# Altium schematic sheet Sheet1.SchDoc
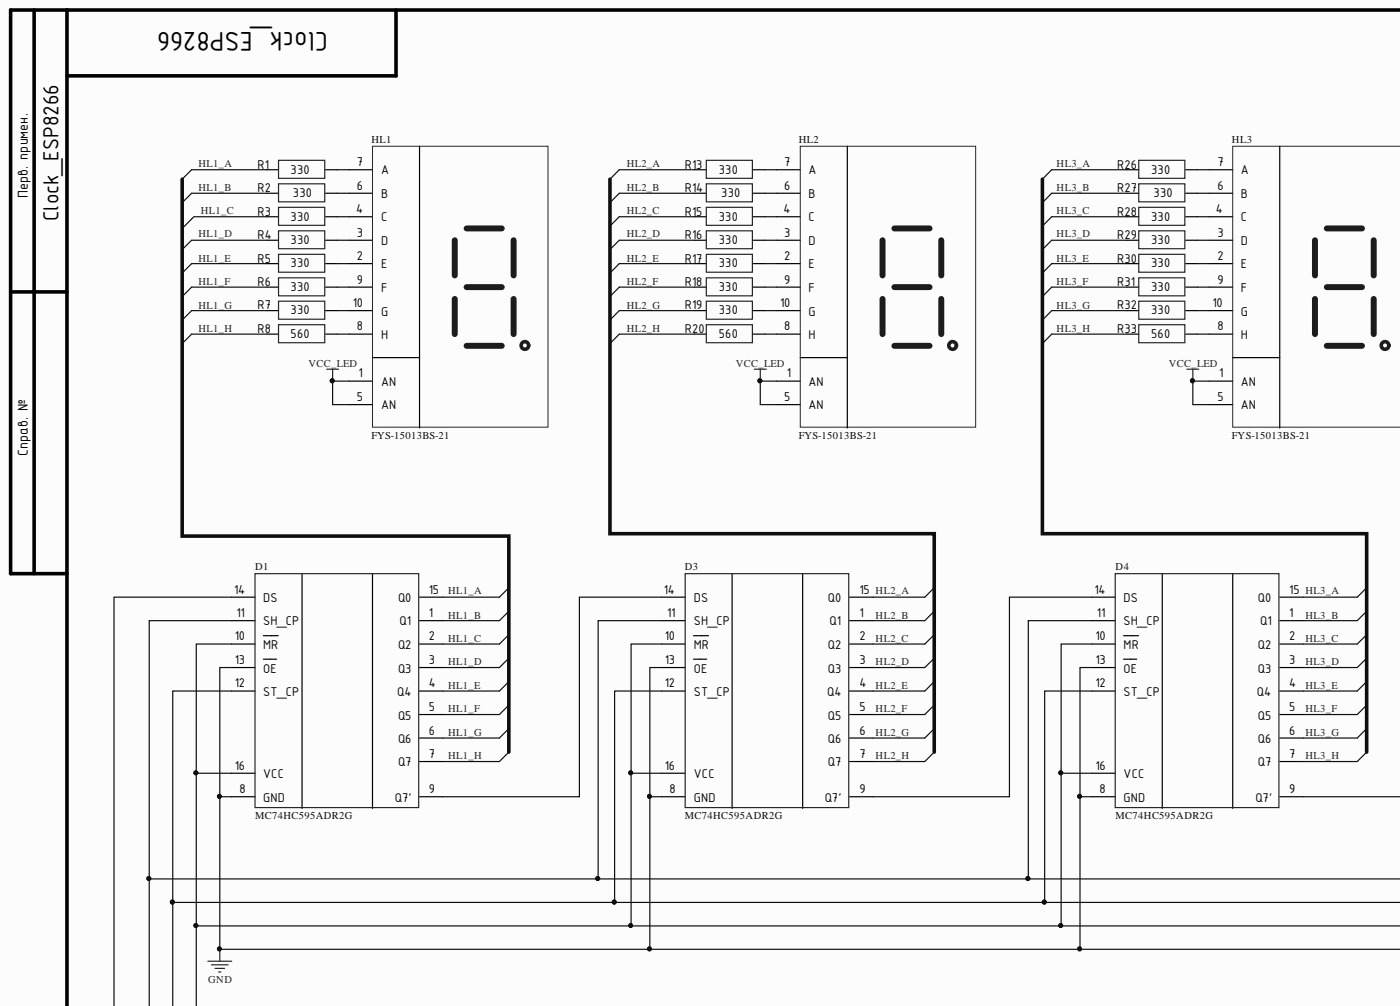
[diagram p0: Sheet1.SchDoc, top left]
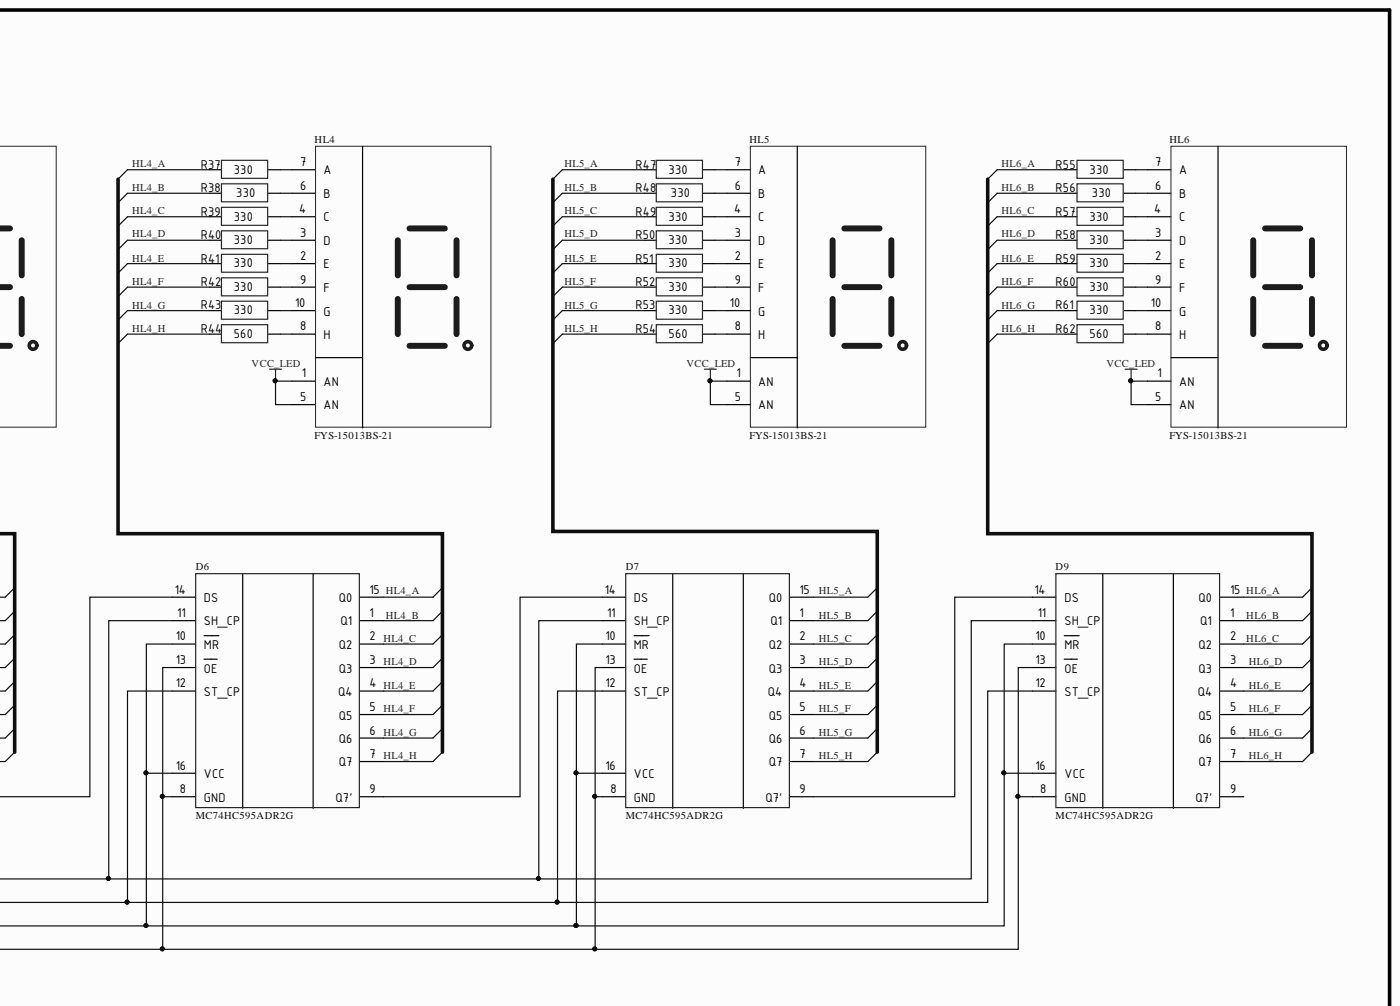
[diagram p1: Sheet1.SchDoc, top right]
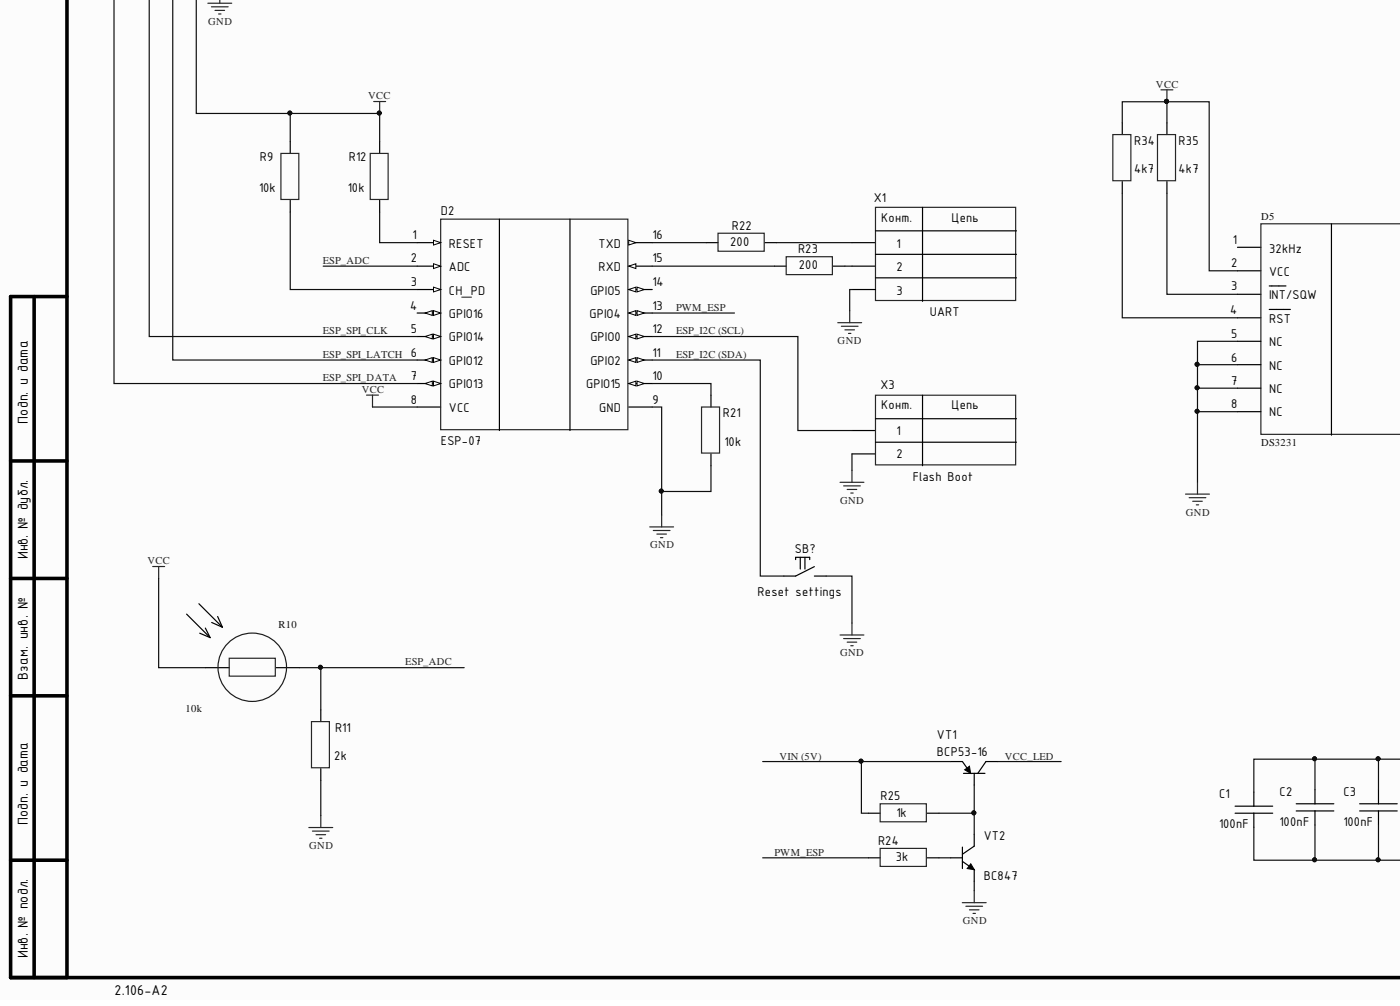
[diagram p2: Sheet1.SchDoc, bottom left]
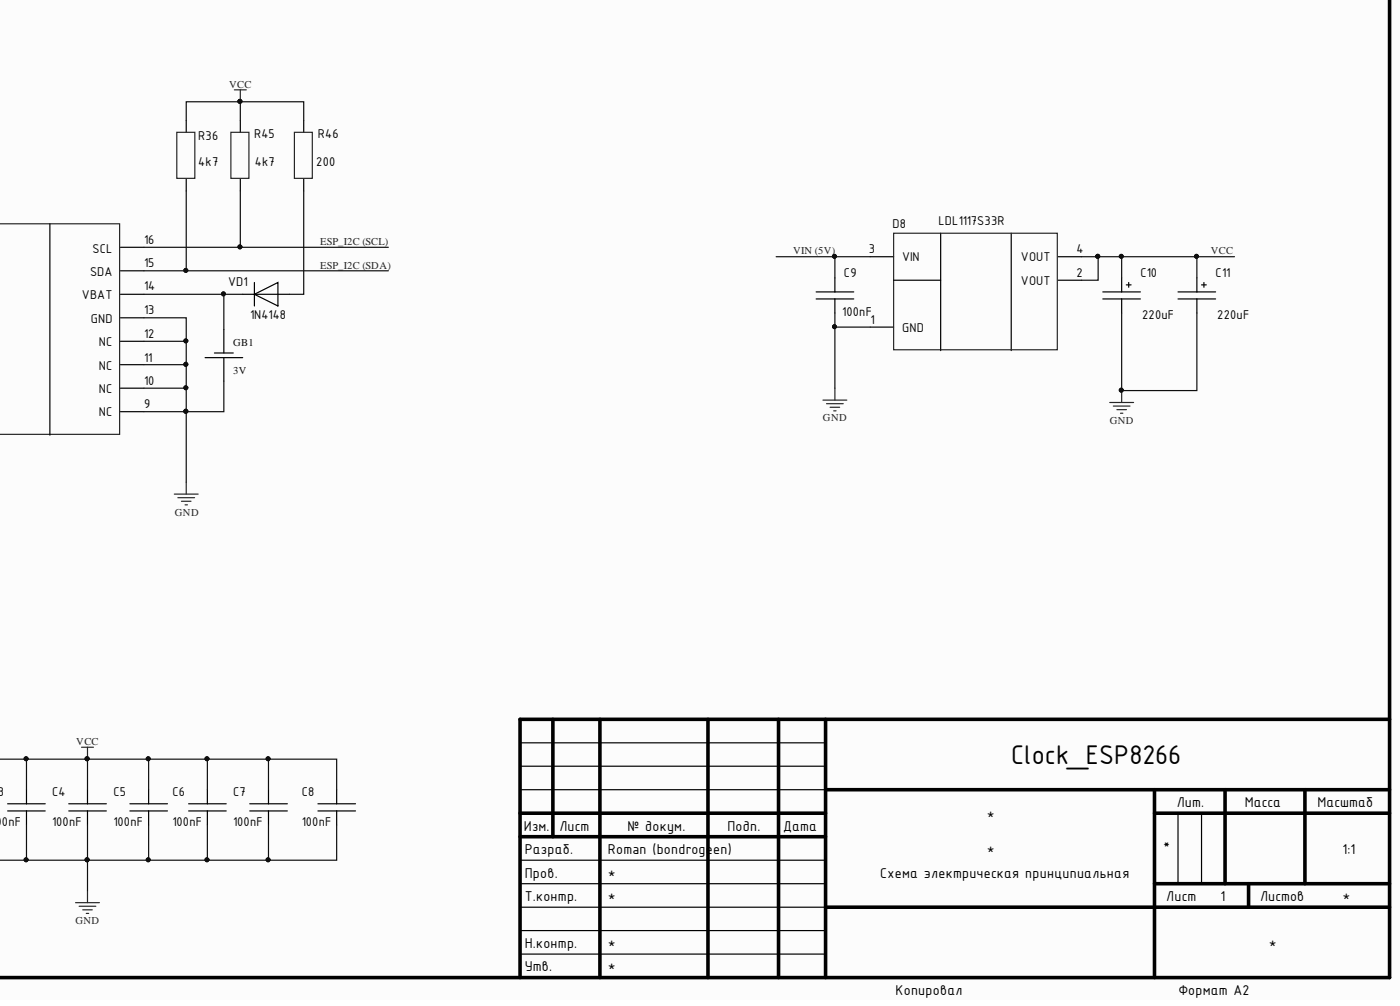
[diagram p3: Sheet1.SchDoc, bottom right]

## Parts
C1  100nF  [CH0603C]
C2  100nF  [CH0603C]
C3  100nF  [CH0603C]
C4  100nF  [CH0603C]
C5  100nF  [CH0603C]
C6  100nF  [CH0603C]
C7  100nF  [CH0603C]
C8  100nF  [CH0603C]
C9  100nF  [CH0805C]
C10  220uF  [TANTAL_C]
C11  220uF  [TANTAL_C]
D1  MC74HC595ADR2G  [16-SOIC]
D2  ESP-07  [MOD: ESP-12E]
D3  MC74HC595ADR2G  [16-SOIC]
D4  MC74HC595ADR2G  [16-SOIC]
D5  DS3231  [16-SOIC (W)]
D6  MC74HC595ADR2G  [16-SOIC]
D7  MC74HC595ADR2G  [16-SOIC]
D8  LDL1117S33R  [SOT223]
D9  MC74HC595ADR2G  [16-SOIC]
GB1  3V  [KLS5-CR2032-01]
HL1  FYS-15013BS-21  [7-seg ( 44 X 30.6)]
HL2  FYS-15013BS-21  [7-seg ( 44 X 30.6)]
HL3  FYS-15013BS-21  [7-seg ( 44 X 30.6)]
HL4  FYS-15013BS-21  [7-seg ( 44 X 30.6)]
HL5  FYS-15013BS-21  [7-seg ( 44 X 30.6)]
HL6  FYS-15013BS-21  [7-seg ( 44 X 30.6)]
R1  330  [CH0805R]
R2  330  [CH0805R]
R3  330  [CH0805R]
R4  330  [CH0805R]
R5  330  [CH0805R]
R6  330  [CH0805R]
R7  330  [CH0805R]
R8  560  [CH0805R]
R9  10k  [CH0805R]
R10  10k  [CON: PBS-2]
R11  2k  [CH0805R]
R12  10k  [CH0805R]
R13  330  [CH0805R]
R14  330  [CH0805R]
R15  330  [CH0805R]
R16  330  [CH0805R]
R17  330  [CH0805R]
R18  330  [CH0805R]
R19  330  [CH0805R]
R20  560  [CH0805R]
R21  10k  [CH0805R]
R22  200  [CH0805R]
R23  200  [CH0805R]
R24  3k  [CH0805R]
R25  1k  [CH0805R]
R26  330  [CH0805R]
R27  330  [CH0805R]
R28  330  [CH0805R]
R29  330  [CH0805R]
R30  330  [CH0805R]
R31  330  [CH0805R]
R32  330  [CH0805R]
R33  560  [CH0805R]
R34  4k7  [CH0805R]
R35  4k7  [CH0805R]
R36  4k7  [CH0805R]
R37  330  [CH0805R]
R38  330  [CH0805R]
R39  330  [CH0805R]
R40  330  [CH0805R]
R41  330  [CH0805R]
R42  330  [CH0805R]
R43  330  [CH0805R]
R44  560  [CH0805R]
R45  4k7  [CH0805R]
R46  200  [CH0805R]
R47  330  [CH0805R]
R48  330  [CH0805R]
R49  330  [CH0805R]
R50  330  [CH0805R]
R51  330  [CH0805R]
R52  330  [CH0805R]
R53  330  [CH0805R]
R54  560  [CH0805R]
R55  330  [CH0805R]
R56  330  [CH0805R]
R57  330  [CH0805R]
R58  330  [CH0805R]
R59  330  [CH0805R]
R60  330  [CH0805R]
R61  330  [CH0805R]
R62  560  [CH0805R]
SB?  Reset settings  [BUT: SKQGADE010]
VD1  1N4148  [SOD80]
VT1  BCP53-16  [SOT223]
VT2  BC847  [SOT23_123]
X1  UART  [CON: PBS-3]
X3  Flash Boot  [CON: PBS-2]

## Nets
GND: C1.1 C2.1 C3.1 C4.1 C5.1 C6.1 C7.1 C8.1 C9.1 C10.2 C11.2 D1.8(GND) D1.13(OE) D2.9(GND) D3.8(GND) D3.13(OE) D4.8(GND) D4.13(OE) D5.5(NC) D5.6(NC) D5.7(NC) D5.8(NC) D5.9(NC) D5.10(NC) D5.11(NC) D5.12(NC) D5.13(GND) D6.8(GND) D6.13(OE) D7.8(GND) D7.13(OE) D8.1(GND) D9.8(GND) D9.13(OE) GB1.2 R11.1 R21.1 SB?.2 VT2.3 X1.3 X3.2
ESP_ADC: D2.2(ADC) R10.2 R11.2
ESP_I2C (SCL): D2.12(GPIO0) D5.16(SCL) R45.1 X3.1
ESP_I2C (SDA): D2.11(GPIO2) D5.15(SDA) R36.1 SB?.1
ESP_SPI_CLK: D1.11(SH_CP) D2.5(GPIO14) D3.11(SH_CP) D4.11(SH_CP) D6.11(SH_CP) D7.11(SH_CP) D9.11(SH_CP)
ESP_SPI_DATA: D1.14(DS) D2.7(GPIO13)
ESP_SPI_LATCH: D1.12(ST_CP) D2.6(GPIO12) D3.12(ST_CP) D4.12(ST_CP) D6.12(ST_CP) D7.12(ST_CP) D9.12(ST_CP)
HL1_A: D1.15(Q0) R1.1
HL1_B: D1.1(Q1) R2.1
HL1_C: D1.2(Q2) R3.1
HL1_D: D1.3(Q3) R4.1
HL1_E: D1.4(Q4) R5.1
HL1_F: D1.5(Q5) R6.1
HL1_G: D1.6(Q6) R7.1
HL1_H: D1.7(Q7) R8.1
HL2_A: D3.15(Q0) R13.1
HL2_B: D3.1(Q1) R14.1
HL2_C: D3.2(Q2) R15.1
HL2_D: D3.3(Q3) R16.1
HL2_E: D3.4(Q4) R17.1
HL2_F: D3.5(Q5) R18.1
HL2_G: D3.6(Q6) R19.1
HL2_H: D3.7(Q7) R20.1
HL3_A: D4.15(Q0) R26.1
HL3_B: D4.1(Q1) R27.1
HL3_C: D4.2(Q2) R28.1
HL3_D: D4.3(Q3) R29.1
HL3_E: D4.4(Q4) R30.1
HL3_F: D4.5(Q5) R31.1
HL3_G: D4.6(Q6) R32.1
HL3_H: D4.7(Q7) R33.1
HL4_A: D6.15(Q0) R37.1
HL4_B: D6.1(Q1) R38.1
HL4_C: D6.2(Q2) R39.1
HL4_D: D6.3(Q3) R40.1
HL4_E: D6.4(Q4) R41.1
HL4_F: D6.5(Q5) R42.1
HL4_G: D6.6(Q6) R43.1
HL4_H: D6.7(Q7) R44.1
HL5_A: D7.15(Q0) R47.1
HL5_B: D7.1(Q1) R48.1
HL5_C: D7.2(Q2) R49.1
HL5_D: D7.3(Q3) R50.1
HL5_E: D7.4(Q4) R51.1
HL5_F: D7.5(Q5) R52.1
HL5_G: D7.6(Q6) R53.1
HL5_H: D7.7(Q7) R54.1
HL6_A: D9.15(Q0) R55.1
HL6_B: D9.1(Q1) R56.1
HL6_C: D9.2(Q2) R57.1
HL6_D: D9.3(Q3) R58.1
HL6_E: D9.4(Q4) R59.1
HL6_F: D9.5(Q5) R60.1
HL6_G: D9.6(Q6) R61.1
HL6_H: D9.7(Q7) R62.1
PWM_ESP: D2.13(GPIO4) R24.1
VCC: C1.2 C2.2 C3.2 C4.2 C5.2 C6.2 C7.2 C8.2 C10.1 C11.1 D1.10(MR) D1.16(VCC) D2.8(VCC) D3.10(MR) D3.16(VCC) D4.10(MR) D4.16(VCC) D5.2(VCC) D6.10(MR) D6.16(VCC) D7.10(MR) D7.16(VCC) D8.2(VOUT) D8.4(VOUT) D9.10(MR) D9.16(VCC) R9.2 R10.1 R12.2 R34.2 R35.2 R36.2 R45.2 R46.1
VCC_LED: HL1.1(AN) HL1.5(AN) HL2.1(AN) HL2.5(AN) HL3.1(AN) HL3.5(AN) HL4.1(AN) HL4.5(AN) HL5.1(AN) HL5.5(AN) HL6.1(AN) HL6.5(AN) VT1.3
VIN (5V): C9.2 D8.3(VIN) R25.1 VT1.2
NetD1_9: D1.9(Q7') D3.14(DS)
NetD2_1: D2.1(RESET) R12.1
NetD2_3: D2.3(CH_PD) R9.1
NetD2_10: D2.10(GPIO15) R21.2
NetD2_15: D2.15(RXD) R23.1
NetD2_16: D2.16(TXD) R22.1
NetD3_9: D3.9(Q7') D4.14(DS)
NetD4_9: D4.9(Q7') D6.14(DS)
NetD5_3: D5.3(INT/SQW) R35.1
NetD5_4: D5.4(RST) R34.1
NetD5_14: D5.14(VBAT) GB1.1 VD1.2
NetD6_9: D6.9(Q7') D7.14(DS)
NetD7_9: D7.9(Q7') D9.14(DS)
NetHL1_2: HL1.2(E) R5.2
NetHL1_3: HL1.3(D) R4.2
NetHL1_4: HL1.4(C) R3.2
NetHL1_6: HL1.6(B) R2.2
NetHL1_7: HL1.7(A) R1.2
NetHL1_8: HL1.8(H) R8.2
NetHL1_9: HL1.9(F) R6.2
NetHL1_10: HL1.10(G) R7.2
NetHL2_2: HL2.2(E) R17.2
NetHL2_3: HL2.3(D) R16.2
NetHL2_4: HL2.4(C) R15.2
NetHL2_6: HL2.6(B) R14.2
NetHL2_7: HL2.7(A) R13.2
NetHL2_8: HL2.8(H) R20.2
NetHL2_9: HL2.9(F) R18.2
NetHL2_10: HL2.10(G) R19.2
NetHL3_2: HL3.2(E) R30.2
NetHL3_3: HL3.3(D) R29.2
NetHL3_4: HL3.4(C) R28.2
NetHL3_6: HL3.6(B) R27.2
NetHL3_7: HL3.7(A) R26.2
NetHL3_8: HL3.8(H) R33.2
NetHL3_9: HL3.9(F) R31.2
NetHL3_10: HL3.10(G) R32.2
NetHL4_2: HL4.2(E) R41.2
NetHL4_3: HL4.3(D) R40.2
NetHL4_4: HL4.4(C) R39.2
NetHL4_6: HL4.6(B) R38.2
NetHL4_7: HL4.7(A) R37.2
NetHL4_8: HL4.8(H) R44.2
NetHL4_9: HL4.9(F) R42.2
NetHL4_10: HL4.10(G) R43.2
NetHL5_2: HL5.2(E) R51.2
NetHL5_3: HL5.3(D) R50.2
NetHL5_4: HL5.4(C) R49.2
NetHL5_6: HL5.6(B) R48.2
NetHL5_7: HL5.7(A) R47.2
NetHL5_8: HL5.8(H) R54.2
NetHL5_9: HL5.9(F) R52.2
NetHL5_10: HL5.10(G) R53.2
NetHL6_2: HL6.2(E) R59.2
NetHL6_3: HL6.3(D) R58.2
NetHL6_4: HL6.4(C) R57.2
NetHL6_6: HL6.6(B) R56.2
NetHL6_7: HL6.7(A) R55.2
NetHL6_8: HL6.8(H) R62.2
NetHL6_9: HL6.9(F) R60.2
NetHL6_10: HL6.10(G) R61.2
NetR22_2: R22.2 X1.1
NetR23_2: R23.2 X1.2
NetR24_2: R24.2 VT2.1
NetR25_2: R25.2 VT1.1 VT2.2
NetR46_2: R46.2 VD1.1
N00056:
N00057:
N00058:
N00059:
N00060:
N00061:

Not connected: D2 4(GPIO16) 14(GPIO5); D5 1(32kHz); D9 9(Q7')

## Library data of the parts
C1-C9 = Capacitor: Component Kind: Standard; Library Name: Discrete.IntLib; Value: 100nF
C10 C11 = TantalCap: Component Kind: Standard; Library Name: Discrete.IntLib; Value: 220uF
D1 D3 D4 D6 D7 D9 = 74HC595: 8-Bit Shift Registers With 3-State Output Registers; Manufacture: ON Semiconductor; Case: 16-SOIC; Component Kind: Standard; HelpURL: http://www.ti.com/lit/ds/symlink/sn74hc595.pdf; Library Name: Logic.IntLib; Model: MC74HC595ADR2G
D2 = ESP-07: Wi-Fi; Manufacturer: China; Component Kind: Standard; ComponentKind: Wi-Fi 2.4GHz; HelpURL: https://ru.aliexpress.com/wholesale?minPrice=&maxPrice=&isBigSale=n&isFreeShip=y&isNew=n&isFavorite=n&isMobileExclusive=n&isLocalReturn=n&shipFromCountry=&shipCompanies=&SearchText=ESP-07&CatId=0&initiative_id=SB_20170806224230&needQuery=y; Library Name: Module.IntLib; Model: ESP-07
D5 = DS3231: Extremely Accurate I2C-Integrated  RTC/TCXO/Crystal; Manufacture: Maxim; Case: 16SOICW; Component Kind: Standard; HelpURL: https://datasheets.maximintegrated.com/en/ds/DS3231.pdf; Library Name: Digital.IntLib; Model: DS3231
D8 = LDL1117S33R: LDO; Manufacturer: STMicroelectronics; Component Kind: Standard; ComponentKind: SOT-223; HelpURL: https://www.digikey.com/product-detail/en/stmicroelectronics/LDL1117S33R/497-17239-1-ND/7102079; Library Name: Power Supplies.IntLib; Model: LDL1117S33R
GB1 = Battery: Manufacture: Vishay Semiconductors; Model: 1N4148; Component Kind: Standard; HelpURL: https://www.vishay.com/docs/81857/1n4148.pdf; Library Name: Discrete.IntLib; Value: 3V
HL1-HL6 = FYS-15013BS-21: 7-segment Display; Manufacture: FORYARD; Component Kind: Standard; HelpURL: http://www.chipnn.ru/doc/all/4043/4043.zip; Library Name: LCD.IntLib; Model: 7-segment Display
R1-R9 R11-R62 = Resistor: Component Kind: Standard; Library Name: Discrete.IntLib
  R1-R7 R13-R19 R26-R32 R37-R43 R47-R53 R55-R61: Value: 330
  R8 R20 R33 R44 R54 R62: Value: 560
  R9 R12 R21: Value: 10k
  R11: Value: 2k
  R22 R23 R46: Value: 200
  R24: Value: 3k
  R25: Value: 1k
  R34-R36 R45: Value: 4k7
R10 = Photoresistor: Component Kind: Standard; Library Name: Discrete.IntLib; Value: 10k
SB? = Tactile switches: 6x6 mm; Component Kind: Standard; Library Name: Connectors.IntLib
VD1 = Diod: Manufacturer: Vishay Semiconductors; Component Kind: Standard; ComponentKind: SOD80; HelpURL: https://www.vishay.com/docs/81857/1n4148.pdf; Library Name: Diods.IntLib; Model: 1N4148
VT1 = PNP: Bipolar; Manufacturer: NXP Semiconductors; Component Kind: Standard; ComponentKind: SOT223; HelpURL: https://assets.nexperia.com/documents/data-sheet/BCP53_BCX53_BC53PA.pdf; Library Name: Transistor.IntLib; Model: BCP53-16
VT2 = NPN: Bipolar; Manufacturer: NXP Semiconductors; Component Kind: Standard; ComponentKind: SOT23; HelpURL: https://assets.nexperia.com/documents/data-sheet/BC847_SER.pdf; Library Name: Transistor.IntLib; Model: BC847
X1 = 3-Connector: Component Kind: Standard; Library Name: Connectors.IntLib
X3 = 2-Connector: Component Kind: Standard; Library Name: Connectors.IntLib
